# Altium schematic sheet 控制核心.SchDoc
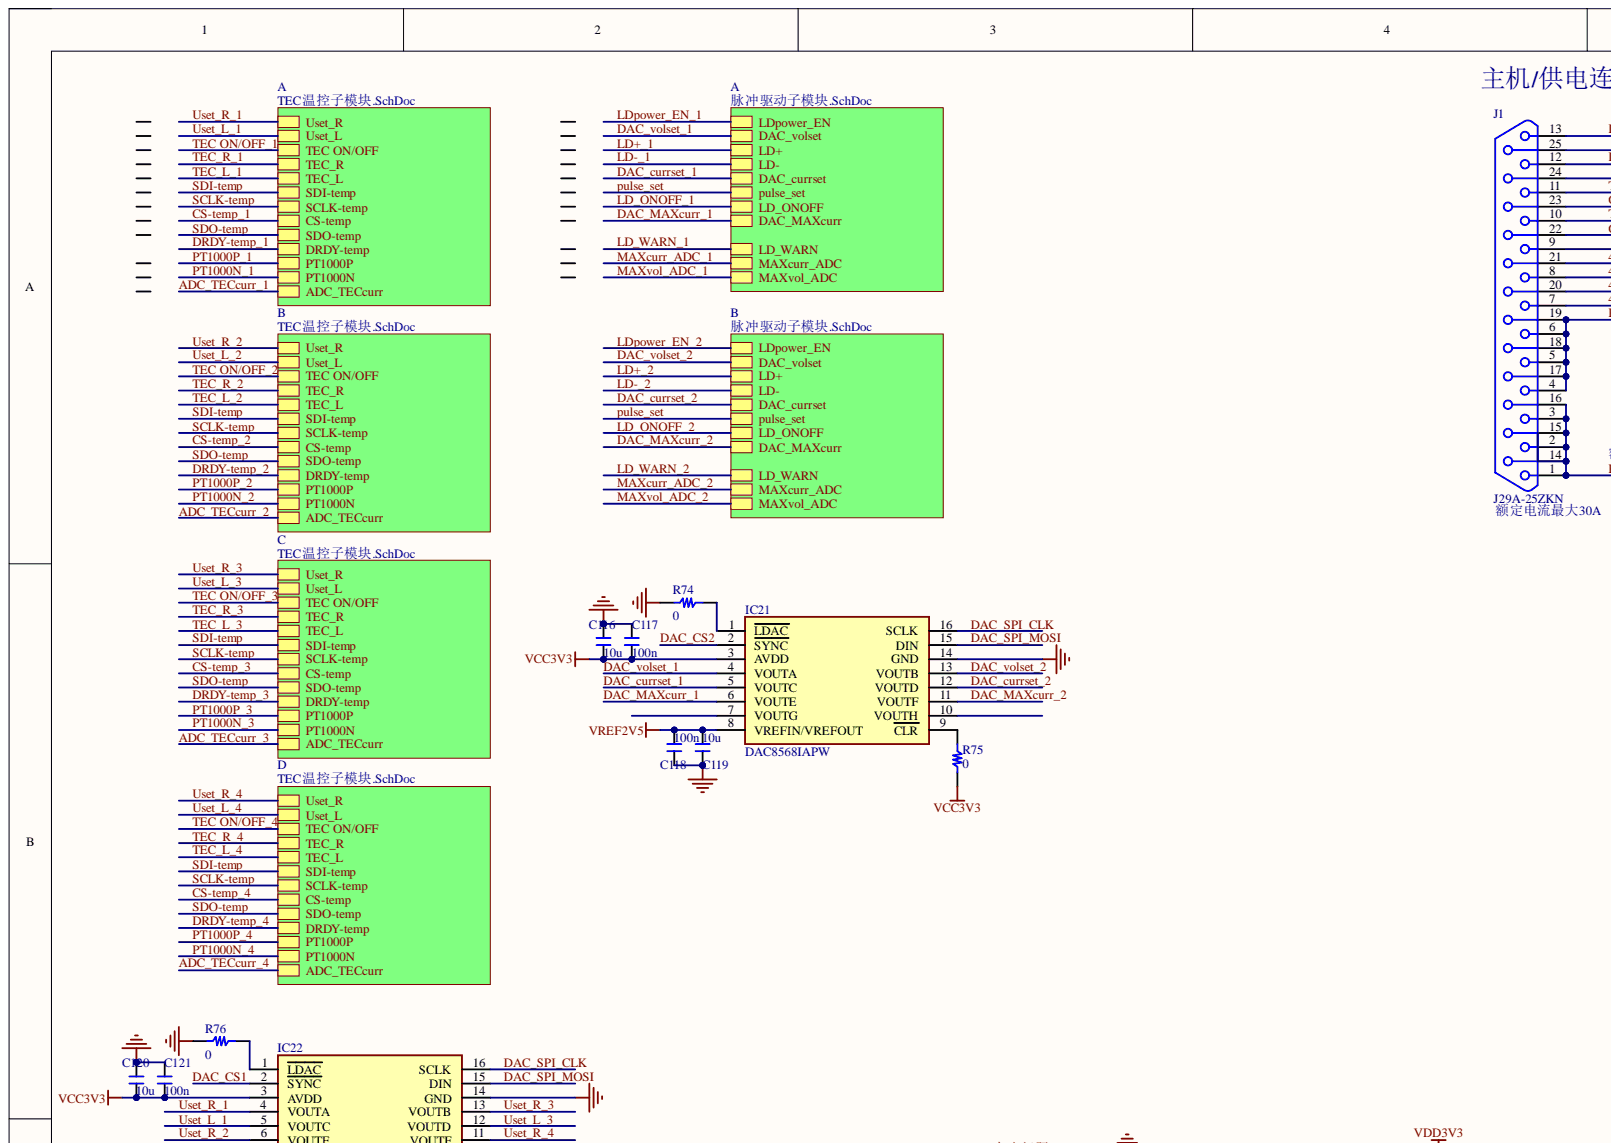
[diagram p0: 控制核心.SchDoc, top left]
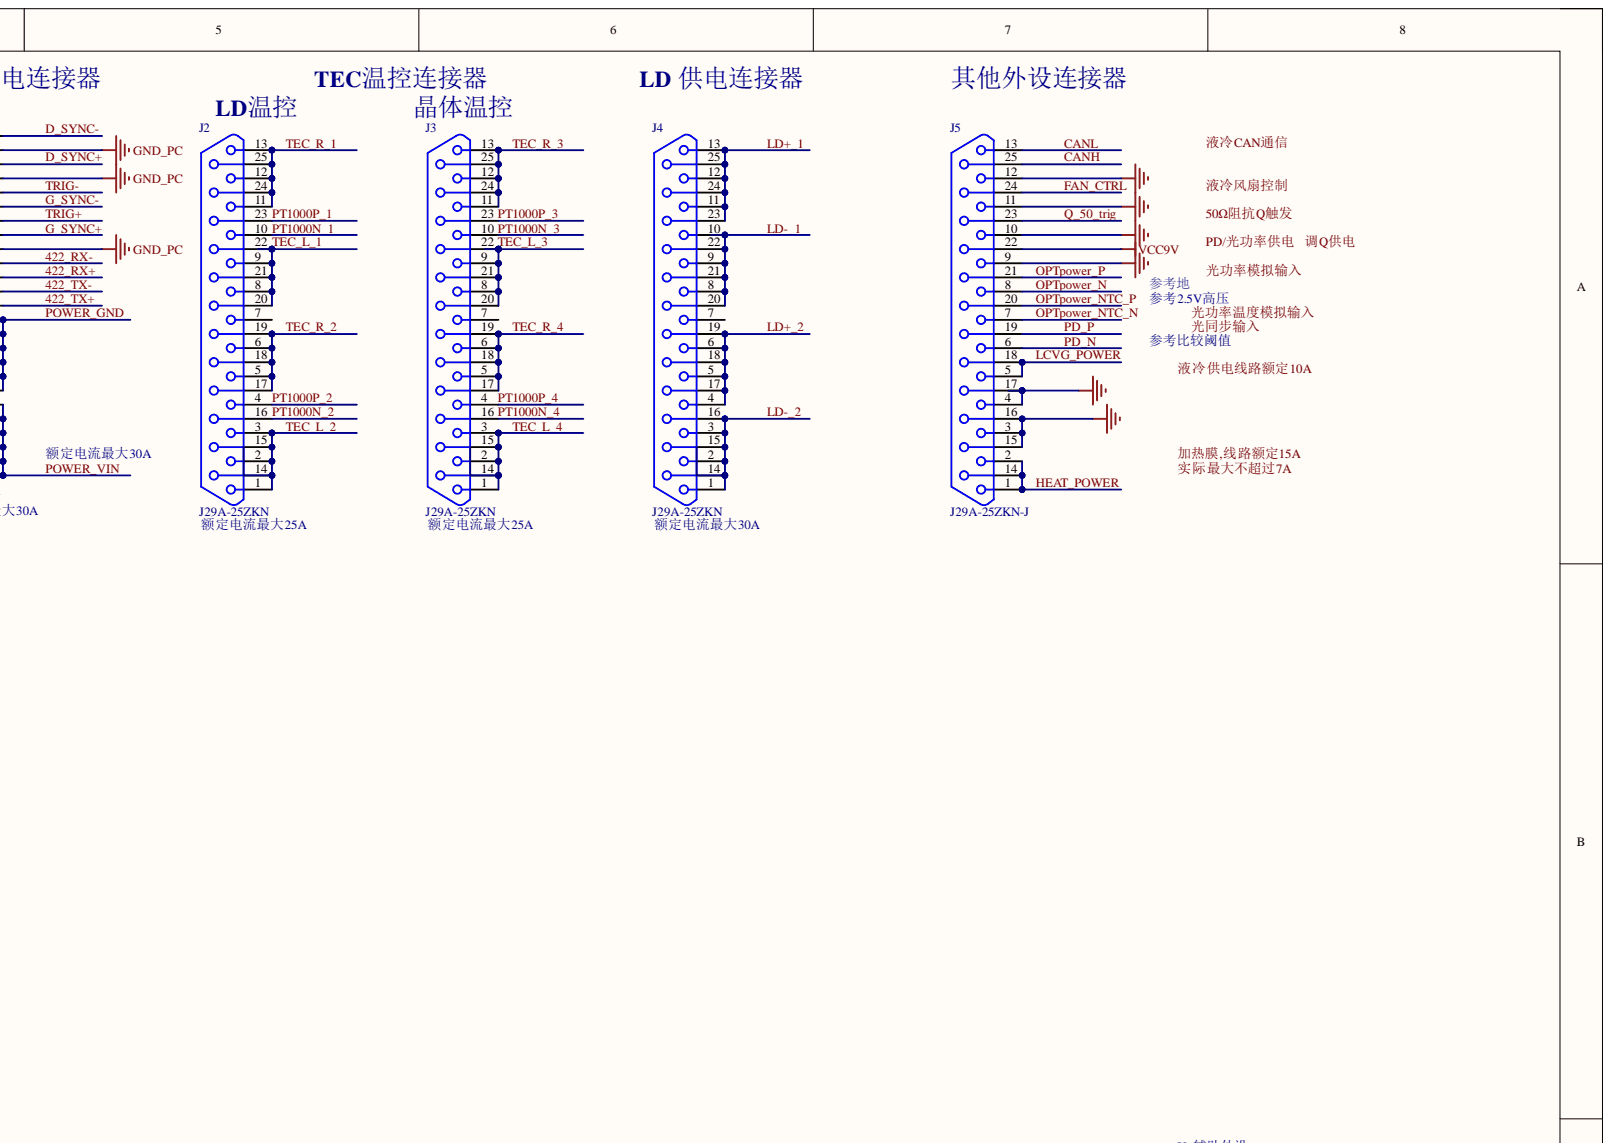
[diagram p1: 控制核心.SchDoc, top right]
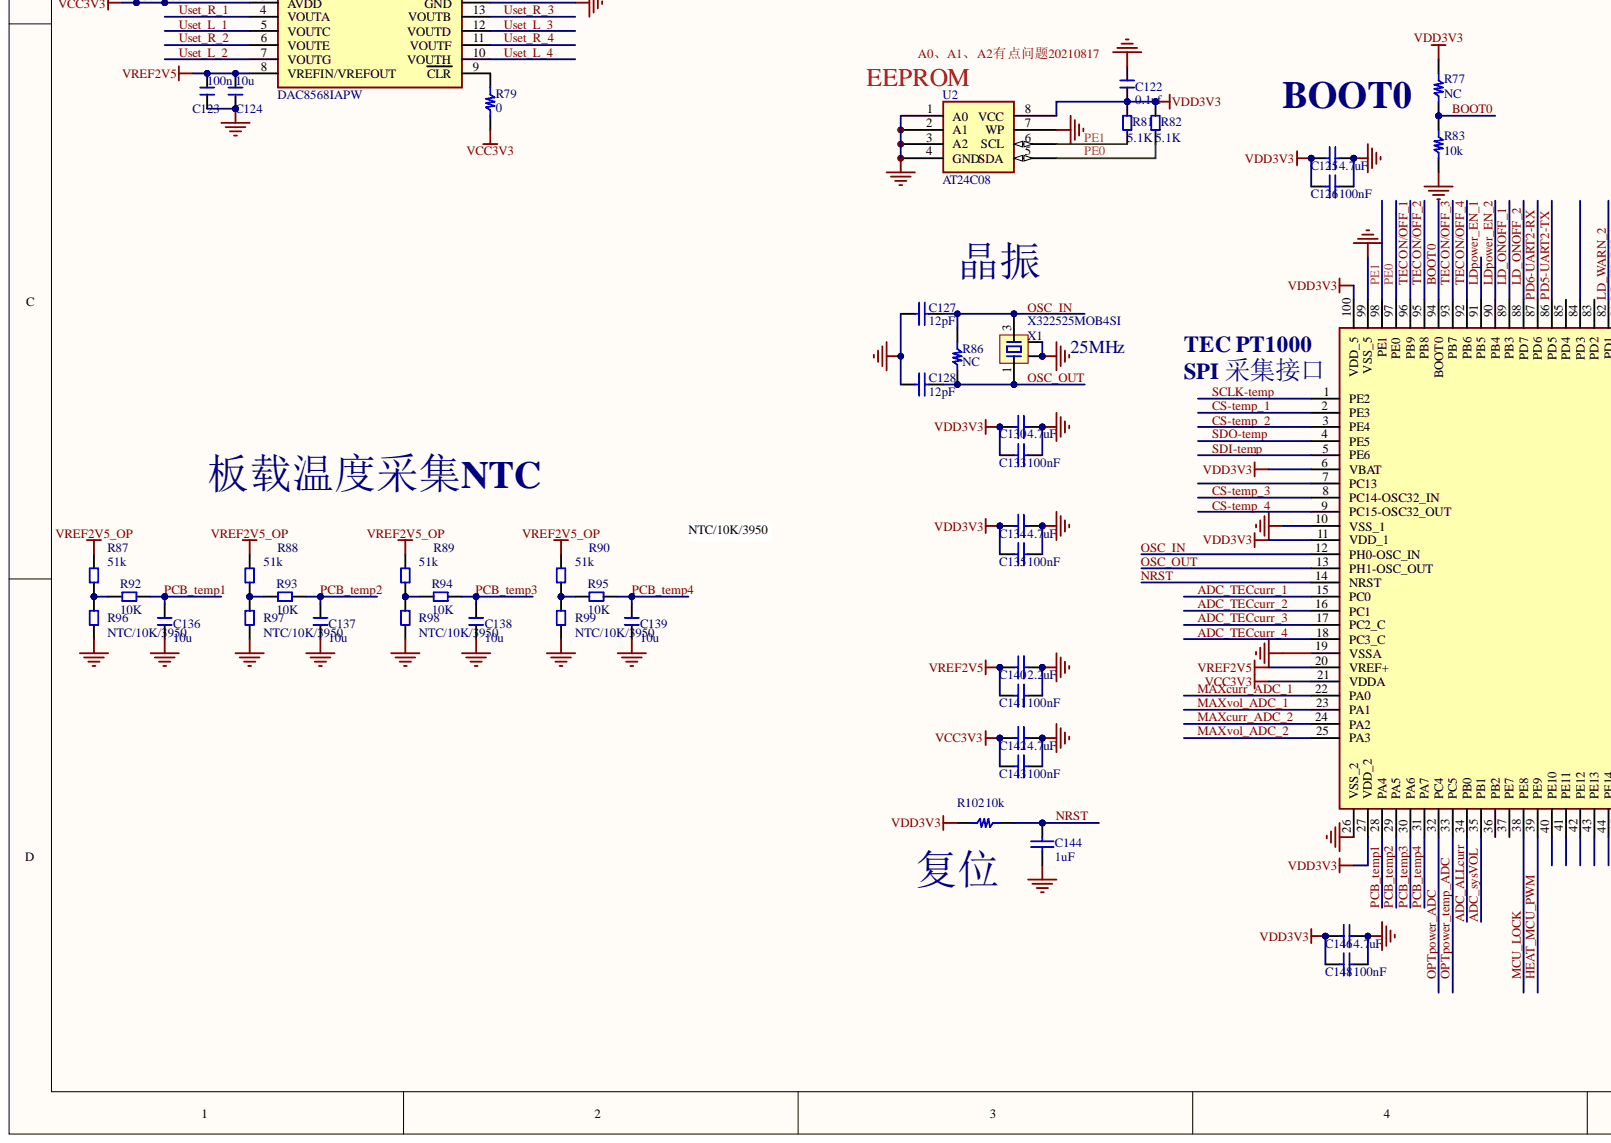
[diagram p2: 控制核心.SchDoc, bottom left]
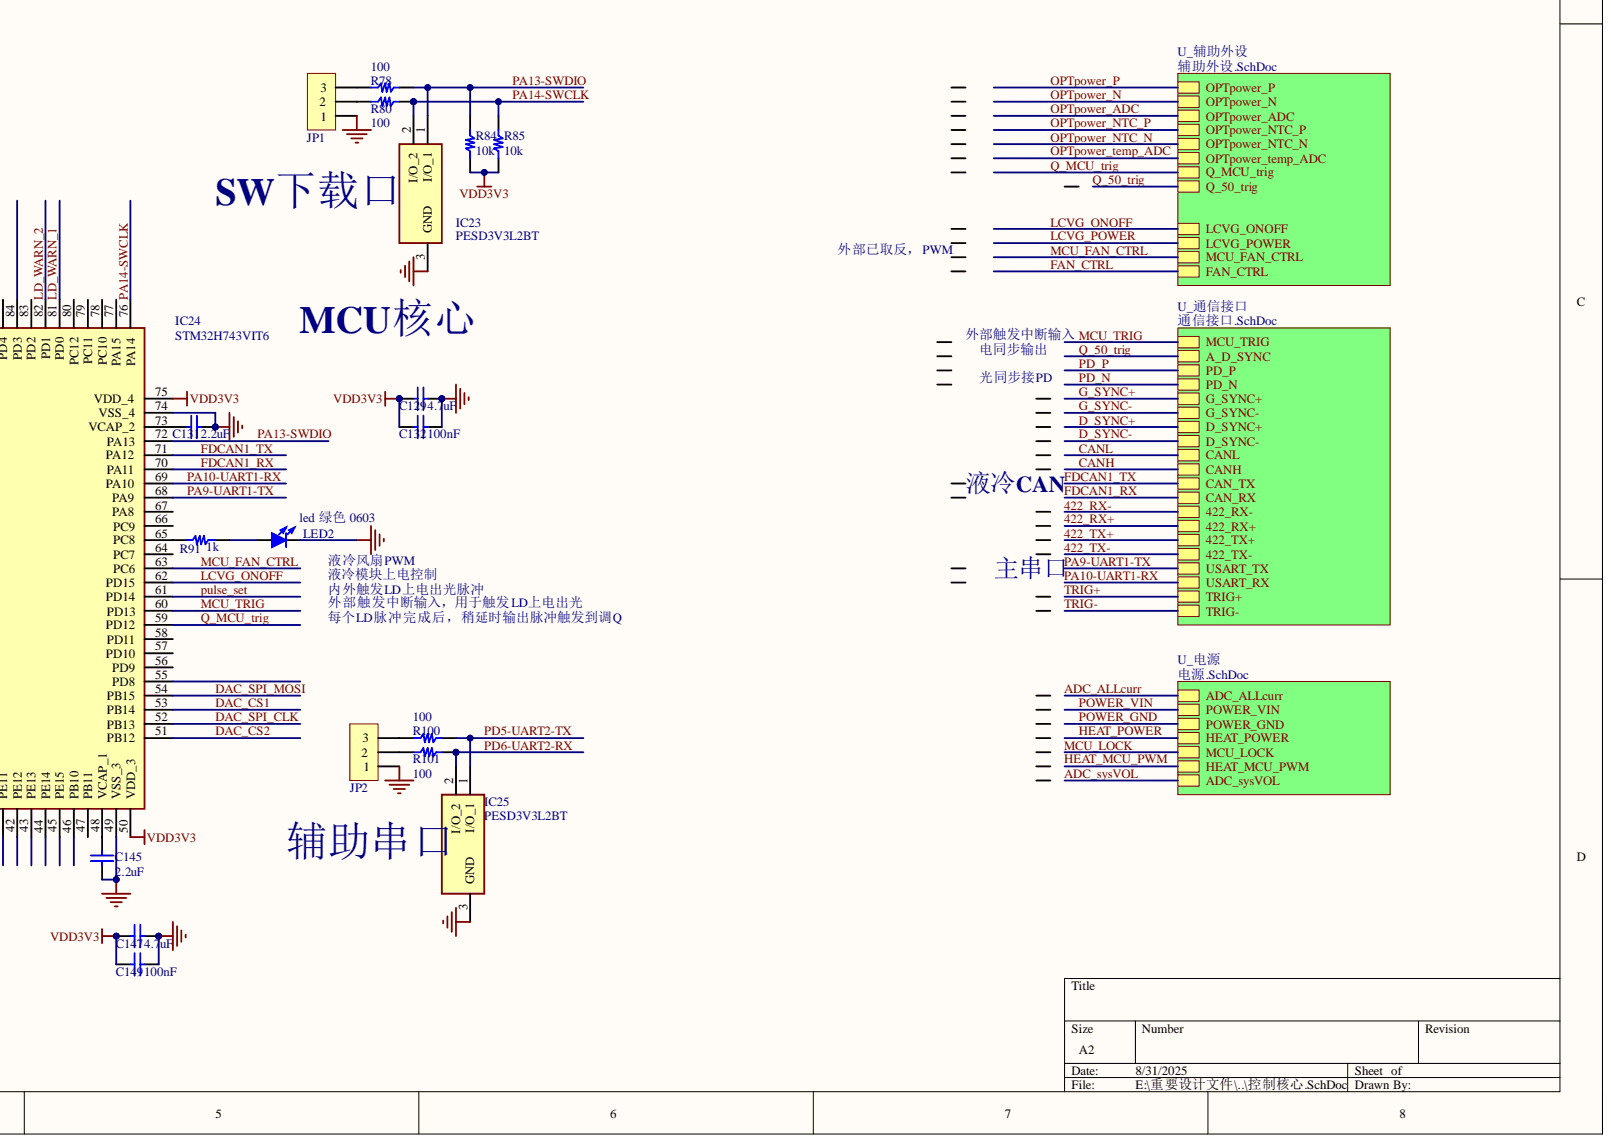
[diagram p3: 控制核心.SchDoc, bottom right]

## Parts
C116  10u  [C 0603_L]
C117  100n  [C 0603_L]
C118  100n  [C 0603_L]
C119  10u  [C 0603_L]
C120  10u  [C 0603_L]
C121  100n  [C 0603_L]
C122  0.1uf  [C 0402_M]
C123  100n  [C 0603_L]
C124  10u  [C 0603_L]
C125  4.7uF  [C 0603_L]
C126  100nF  [C 0603_L]
C127  12pF  [C 0603_L]
C128  12pF  [C 0603_L]
C129  4.7uF  [C 0603_L]
C130  4.7uF  [C 0603_L]
C131  2.2uF  [C 0603_L]
C132  100nF  [C 0603_L]
C133  100nF  [C 0603_L]
C134  4.7uF  [C 0603_L]
C135  100nF  [C 0603_L]
C136  10u  [C 0603_L]
C137  10u  [C 0603_L]
C138  10u  [C 0603_L]
C139  10u  [C 0603_L]
C140  2.2uF  [C 0603_L]
C141  100nF  [C 0603_L]
C142  4.7uF  [C 0603_L]
C143  100nF  [C 0603_L]
C144  1uF  [C 0603_L]
C145  2.2uF  [C 0603_L]
C146  4.7uF  [C 0603_L]
C147  4.7uF  [C 0603_L]
C148  100nF  [C 0603_L]
C149  100nF  [C 0603_L]
IC21  DAC8568IAPW  [SOP65P640X120-16N]
IC22  DAC8568IAPW  [SOP65P640X120-16N]
IC23  PESD3V3L2BT  [SOT23-3L]
IC24  STM32H743VIT6  [QFP50P1600X1600X160-100N]
IC25  PESD3V3L2BT  [SOT23-3L]
J1  J29A-25ZKN  [J29A-25ZKN-J]
J2  J29A-25ZKN  [J29A-25ZKN-J]
J3  J29A-25ZKN  [J29A-25ZKN-J]
J4  J29A-25ZKN  [J29A-25ZKN-J]
J5  J29A-25ZKN-J  [J29A-25ZKN-J]
JP1  HDR-1X3  [HDR2.54-LI-3P]  (value not drawn)
JP2  HDR-1X3  [HDR2.54-LI-3P]  (value not drawn)
LED2  led 绿色 0603  [LED 0603B]
R74  0  [R 0603_L]
R75  0  [R 0603_L]
R76  0  [R 0603_L]
R77  NC  [R 0603_L]
R78  100  [R 0603_L]
R79  0  [R 0603_L]
R80  100  [R 0603_L]
R81  5.1K  [R 0603_l]
R82  5.1K  [R 0603_l]
R83  10k  [R 0603_L]
R84  10k  [R 0603_L]
R85  10k  [R 0603_L]
R86  NC  [R 0603_L]
R87  51k  [R 0603_l]
R88  51k  [R 0603_l]
R89  51k  [R 0603_l]
R90  51k  [R 0603_l]
R91  1k  [R 0603_L]
R92  10K  [R 0603_l]
R93  10K  [R 0603_l]
R94  10K  [R 0603_l]
R95  10K  [R 0603_l]
R96  NTC/10K/3950  [R 0603_l]
R97  NTC/10K/3950  [R 0603_l]
R98  NTC/10K/3950  [R 0603_l]
R99  NTC/10K/3950  [R 0603_l]
R100  100  [R 0603_L]
R101  100  [R 0603_L]
R102  10k  [R 0603_L]  (value not drawn)
U2  AT24C08  [SOP8_M]
X1  X322525MOB4SI  [OSC 3225-4P]

## Nets
GND: C116.2 C117.2 C118.2 C119.2 C120.2 C121.2 C122.2 C123.2 C124.2 C125.2 C126.2 C127.1 C128.1 C129.2 C130.2 C131.2 C132.2 C133.2 C134.2 C135.2 C136.1 C137.1 C138.1 C139.1 C140.2 C141.2 C142.2 C143.2 C144.2 C145.2 C146.2 C147.2 C148.2 C149.2 IC21.14(GND) IC22.14(GND) IC23.3 IC24.10(VSS_1) IC24.19(VSSA) IC24.26(VSS_2) IC24.49(VSS_3) IC24.74(VSS_4) IC24.99(VSS_5) IC25.3 J5.3 J5.4 J5.9 J5.10 J5.11 J5.12 J5.15 J5.16 J5.17 JP1.1 JP2.1 LED2.2 R74.2 R76.2 R83.2 R96.1 R97.1 R98.1 R99.1 U2.1(A0) U2.2(A1) U2.3(A2) U2.4(GND) U2.7(WP) X1.2 X1.4
GND_PC: J1.9 J1.24 J1.25
VCC3V3: C116.1 C117.1 C120.1 C121.1 C142.1 C143.1 IC21.3(AVDD) IC22.3(AVDD) IC24.21(VDDA) R75.2 R79.2
VCC9V: J5.22
VDD3V3: C122.1 C125.1 C126.1 C129.1 C130.1 C132.1 C133.1 C134.1 C135.1 C146.1 C147.1 C148.1 C149.1 IC24.6(VBAT) IC24.11(VDD_1) IC24.27(VDD_2) IC24.50(VDD_3) IC24.75(VDD_4) IC24.100(VDD_5) R77.1 R81.2 R82.2 R84.1 R85.1 R102.2 U2.8(VCC)
VREF2V5: C118.1 C119.1 C123.1 C124.1 C140.1 C141.1 IC21.8(VREFIN/VREFOUT) IC22.8(VREFIN/VREFOUT) IC24.20(VREF+)
VREF2V5_OP: R87.2 R88.2 R89.2 R90.2
422_RX+: J1.8
422_RX-: J1.21
422_TX+: J1.7
422_TX-: J1.20
ADC_ALLcurr: IC24.34(PB0)
ADC_sysVOL: IC24.35(PB1)
ADC_TECcurr_1: IC24.15(PC0)
ADC_TECcurr_2: IC24.16(PC1)
ADC_TECcurr_3: IC24.17(PC2_C)
ADC_TECcurr_4: IC24.18(PC3_C)
BOOT0: IC24.94(BOOT0) R77.2 R83.1
CANH: J5.25
CANL: J5.13
CS-temp_1: IC24.2(PE3)
CS-temp_2: IC24.3(PE4)
CS-temp_3: IC24.8(PC14-OSC32_IN)
CS-temp_4: IC24.9(PC15-OSC32_OUT)
D_SYNC+: J1.12
D_SYNC-: J1.13
DAC_CS1: IC22.2(SYNC) IC24.53(PB14)
DAC_CS2: IC21.2(SYNC) IC24.51(PB12)
DAC_currset_1: IC21.5(VOUTC)
DAC_currset_2: IC21.12(VOUTD)
DAC_MAXcurr_1: IC21.6(VOUTE)
DAC_MAXcurr_2: IC21.11(VOUTF)
DAC_SPI_CLK: IC21.16(SCLK) IC22.16(SCLK) IC24.52(PB13)
DAC_SPI_MOSI: IC21.15(DIN) IC22.15(DIN) IC24.54(PB15)
DAC_volset_1: IC21.4(VOUTA)
DAC_volset_2: IC21.13(VOUTB)
FAN_CTRL: J5.24
FDCAN1_RX: IC24.70(PA11)
FDCAN1_TX: IC24.71(PA12)
G_SYNC+: J1.22
G_SYNC-: J1.23
HEAT_MCU_PWM: IC24.39(PE9)
HEAT_POWER: J5.1 J5.2 J5.14
LCVG_ONOFF: IC24.62(PD15)
LCVG_POWER: J5.5 J5.18
LD+_1: J4.11 J4.12 J4.13 J4.23 J4.24 J4.25
LD+_2: J4.4 J4.5 J4.6 J4.17 J4.18 J4.19
LD-_1: J4.8 J4.9 J4.10 J4.20 J4.21 J4.22
LD-_2: J4.1 J4.2 J4.3 J4.14 J4.15 J4.16
LD_ONOFF_1: IC24.89(PB3)
LD_ONOFF_2: IC24.88(PD7)
LD_WARN_1: IC24.81(PD0)
LD_WARN_2: IC24.82(PD1)
LDpower_EN_1: IC24.91(PB5)
LDpower_EN_2: IC24.90(PB4)
MAXcurr_ADC_1: IC24.22(PA0)
MAXcurr_ADC_2: IC24.24(PA2)
MAXvol_ADC_1: IC24.23(PA1)
MAXvol_ADC_2: IC24.25(PA3)
MCU_FAN_CTRL: IC24.63(PC6)
MCU_LOCK: IC24.38(PE8)
MCU_TRIG: IC24.60(PD13)
NRST: C144.1 IC24.14(NRST) R102.1
OPTpower_ADC: IC24.32(PC4)
OPTpower_N: J5.8
OPTpower_NTC_N: J5.7
OPTpower_NTC_P: J5.20
OPTpower_P: J5.21
OPTpower_temp_ADC: IC24.33(PC5)
OSC_IN: C127.2 IC24.12(PH0-OSC_IN) R86.1 X1.3
OSC_OUT: C128.2 IC24.13(PH1-OSC_OUT) R86.2 X1.1
PA9-UART1-TX: IC24.68(PA9)
PA10-UART1-RX: IC24.69(PA10)
PA13-SWDIO: IC23.1 IC24.72(PA13) R78.2 R84.2
PA14-SWCLK: IC23.2 IC24.76(PA14) R80.2 R85.2
PCB_temp1: C136.2 IC24.28(PA4) R92.2
PCB_temp2: C137.2 IC24.29(PA5) R93.2
PCB_temp3: C138.2 IC24.30(PA6) R94.2
PCB_temp4: C139.2 IC24.31(PA7) R95.2
PD5-UART2-TX: IC24.86(PD5) IC25.1 R100.2
PD6-UART2-RX: IC24.87(PD6) IC25.2 R101.2
PD_N: J5.6
PD_P: J5.19
PE0: IC24.97(PE0) R82.1 U2.5(SDA)
PE1: IC24.98(PE1) R81.1 U2.6(SCL)
POWER_GND: J1.4 J1.5 J1.6 J1.17 J1.18 J1.19
POWER_VIN: J1.1 J1.2 J1.3 J1.14 J1.15 J1.16
PT1000N_1: J2.10
PT1000N_2: J2.16
PT1000N_3: J3.10
PT1000N_4: J3.16
PT1000P_1: J2.23
PT1000P_2: J2.4
PT1000P_3: J3.23
PT1000P_4: J3.4
pulse_set: IC24.61(PD14)
Q_50_trig: J5.23
Q_MCU_trig: IC24.59(PD12)
SCLK-temp: IC24.1(PE2)
SDI-temp: IC24.5(PE6)
SDO-temp: IC24.4(PE5)
TEC ON/OFF_1: IC24.96(PB9)
TEC ON/OFF_2: IC24.95(PB8)
TEC ON/OFF_3: IC24.93(PB7)
TEC ON/OFF_4: IC24.92(PB6)
TEC_L_1: J2.8 J2.9 J2.20 J2.21 J2.22
TEC_L_2: J2.1 J2.2 J2.3 J2.14 J2.15
TEC_L_3: J3.8 J3.9 J3.20 J3.21 J3.22
TEC_L_4: J3.1 J3.2 J3.3 J3.14 J3.15
TEC_R_1: J2.11 J2.12 J2.13 J2.24 J2.25
TEC_R_2: J2.5 J2.6 J2.17 J2.18 J2.19
TEC_R_3: J3.11 J3.12 J3.13 J3.24 J3.25
TEC_R_4: J3.5 J3.6 J3.17 J3.18 J3.19
TRIG+: J1.10
TRIG-: J1.11
Uset_L_1: IC22.5(VOUTC)
Uset_L_2: IC22.7(VOUTG)
Uset_L_3: IC22.12(VOUTD)
Uset_L_4: IC22.10(VOUTH)
Uset_R_1: IC22.4(VOUTA)
Uset_R_2: IC22.6(VOUTE)
Uset_R_3: IC22.13(VOUTB)
Uset_R_4: IC22.11(VOUTF)
NetC131_1: C131.1 IC24.73(VCAP_2)
NetC145_1: C145.1 IC24.48(VCAP_1)
NetIC21_1: IC21.1(LDAC) R74.1
NetIC21_7: IC21.7(VOUTG)
NetIC21_9: IC21.9(CLR) R75.1
NetIC21_10: IC21.10(VOUTH)
NetIC22_1: IC22.1(LDAC) R76.1
NetIC22_9: IC22.9(CLR) R79.1
NetIC24_7: IC24.7(PC13)
NetIC24_36: IC24.36(PB2)
NetIC24_37: IC24.37(PE7)
NetIC24_40: IC24.40(PE10)
NetIC24_41: IC24.41(PE11)
NetIC24_42: IC24.42(PE12)
NetIC24_43: IC24.43(PE13)
NetIC24_44: IC24.44(PE14)
NetIC24_45: IC24.45(PE15)
NetIC24_46: IC24.46(PB10)
NetIC24_47: IC24.47(PB11)
NetIC24_55: IC24.55(PD8)
NetIC24_56: IC24.56(PD9)
NetIC24_57: IC24.57(PD10)
NetIC24_58: IC24.58(PD11)
NetIC24_64: IC24.64(PC7)
NetIC24_65: IC24.65(PC8) R91.2
NetIC24_66: IC24.66(PC9)
NetIC24_67: IC24.67(PA8)
NetIC24_77: IC24.77(PA15)
NetIC24_78: IC24.78(PC10)
NetIC24_79: IC24.79(PC11)
NetIC24_80: IC24.80(PC12)
NetIC24_83: IC24.83(PD2)
NetIC24_84: IC24.84(PD3)
NetIC24_85: IC24.85(PD4)
NetJ2_7: J2.7
NetJ3_7: J3.7
NetJ4_7: J4.7
NetJP1_2: JP1.2 R80.1
NetJP1_3: JP1.3 R78.1
NetJP2_2: JP2.2 R101.1
NetJP2_3: JP2.3 R100.1
NetLED2_1: LED2.1 R91.1
NetR87_1: R87.1 R92.1 R96.2
NetR88_1: R88.1 R93.1 R97.2
NetR89_1: R89.1 R94.1 R98.2
NetR90_1: R90.1 R95.1 R99.2
DRDY-temp_1:
DRDY-temp_2:
DRDY-temp_3:
DRDY-temp_4:

## Library data of the parts
C116-C124 C136-C139 = C: 无极性贴片电容; 版权归属: 源创客; BOM名称: 无极性贴片电容; Component Kind: Standard; Library Name: 3.1   - 电阻-电容-电感.SchLib; 学习资源: www.ooocke.com
C125-C135 C140-C149 = Cap: 贴片电容; Value: 100pF; Component Kind: Standard; LatestRevisionDate: 17-Jul-2002; LatestRevisionNote: Re-released for DXP Platform.; Library Name: 416B_MCUV1.2.SCHLIB; PackageReference: RAD-0.3; Published: 8-Jun-2000; Publisher: Altium Limited
IC21 IC22 = DAC8568IAPW: Integrated Circuit; Manufacturer_Name: Texas Instruments; Arrow Part Number: DAC8568IAPW; Arrow Price/Stock: https://www.arrow.com/en/products/dac8568iapw/texas-instruments?region=europe; Component Kind: Standard; Datasheet Link: http://www.ti.com/lit/gpn/dac8568; Height: 1.2mm; Manufacturer_Part_Number: DAC8568IAPW; Mouser Part Number: 595-DAC8568IAPW; Mouser Price/Stock: https://www.mouser.co.uk/ProductDetail/Texas-Instruments/DAC8568IAPW?qs=JxdSYA%2FPj%252BEQdFVS13frJg%3D%3D
IC23 IC25 = PSM712-LF-T7: Integrated Circuit; Manufacturer_Name: ProTek Devices; Arrow Part Number: PSM712-LF-T7; Arrow Price/Stock: https://www.arrow.com/en/products/psm712-lf-t7/protek-devices?region=europe; Component Kind: Standard; Datasheet Link: https://www.arrow.com/en/products/psm712-lf-t7/protek-devices; Height: 1.21mm; Library Name: SamacSys.SchLib; Manufacturer_Part_Number: PSM712-LF-T7
IC24 = STM32H743VGT6: Integrated Circuit; Manufacturer_Name: STMicroelectronics; Arrow Part Number: STM32H743VGT6; Arrow Price/Stock: https://www.arrow.com/en/products/stm32h743vgt6/stmicroelectronics?utm_currency=USD&region=nac; Component Kind: Standard; Datasheet Link: https://componentsearchengine.com/Datasheets/1/STM32H743VGT6.pdf; Height: 1.6mm; Manufacturer_Part_Number: STM32H743VGT6
J1-J5 = DB25: Component Kind: Standard
JP1 JP2 = Header 3: 3P接插件; 版权归属: 源创客; BOM名称: 3P接插件; Component Kind: Standard; Library Name: 4.5   - 接插件-脚距2.54.SchLib; 学习资源: www.ooocke.com
LED2 = LED-SMD: 贴片LED; 版权归属: 源创客; BOM名称: 贴片LED; Component Kind: Standard; Library Name: 9.1   - LED.SchLib; 学习资源: www.ooocke.com
R74-R80 R83-R86 R91 R100-R102 = Res: 电阻; Value: 230k; Code_IPC: RESC2012; Component Kind: Standard; LatestRevisionDate: 29-May-2009; LatestRevisionNote: IPC-7351 Footprint Added.; Library Name: 416B_MCUV1.2.SCHLIB; PackageDescription: Chip Resistor 0805 Case Size; PackageReference: 6-0805; PackageVersion: Mar-2003; Published: 24-Mar-1999; Publisher: Altium Limited
R81 R82 R87-R90 R92-R99 = RES2: Component Kind: Standard
U2 = AT24CXX: Component Kind: Standard
X1 = XTAL-4P: 4脚无源晶振; 版权归属: 源创客; BOM名称: 4脚无源晶振; Component Kind: Standard; Library Name: 10.1 - 保险丝-晶振.SchLib; 学习资源: www.ooocke.com
